# Altium schematic sheet WlPK1_main.SchDoc(WlPK1_main)
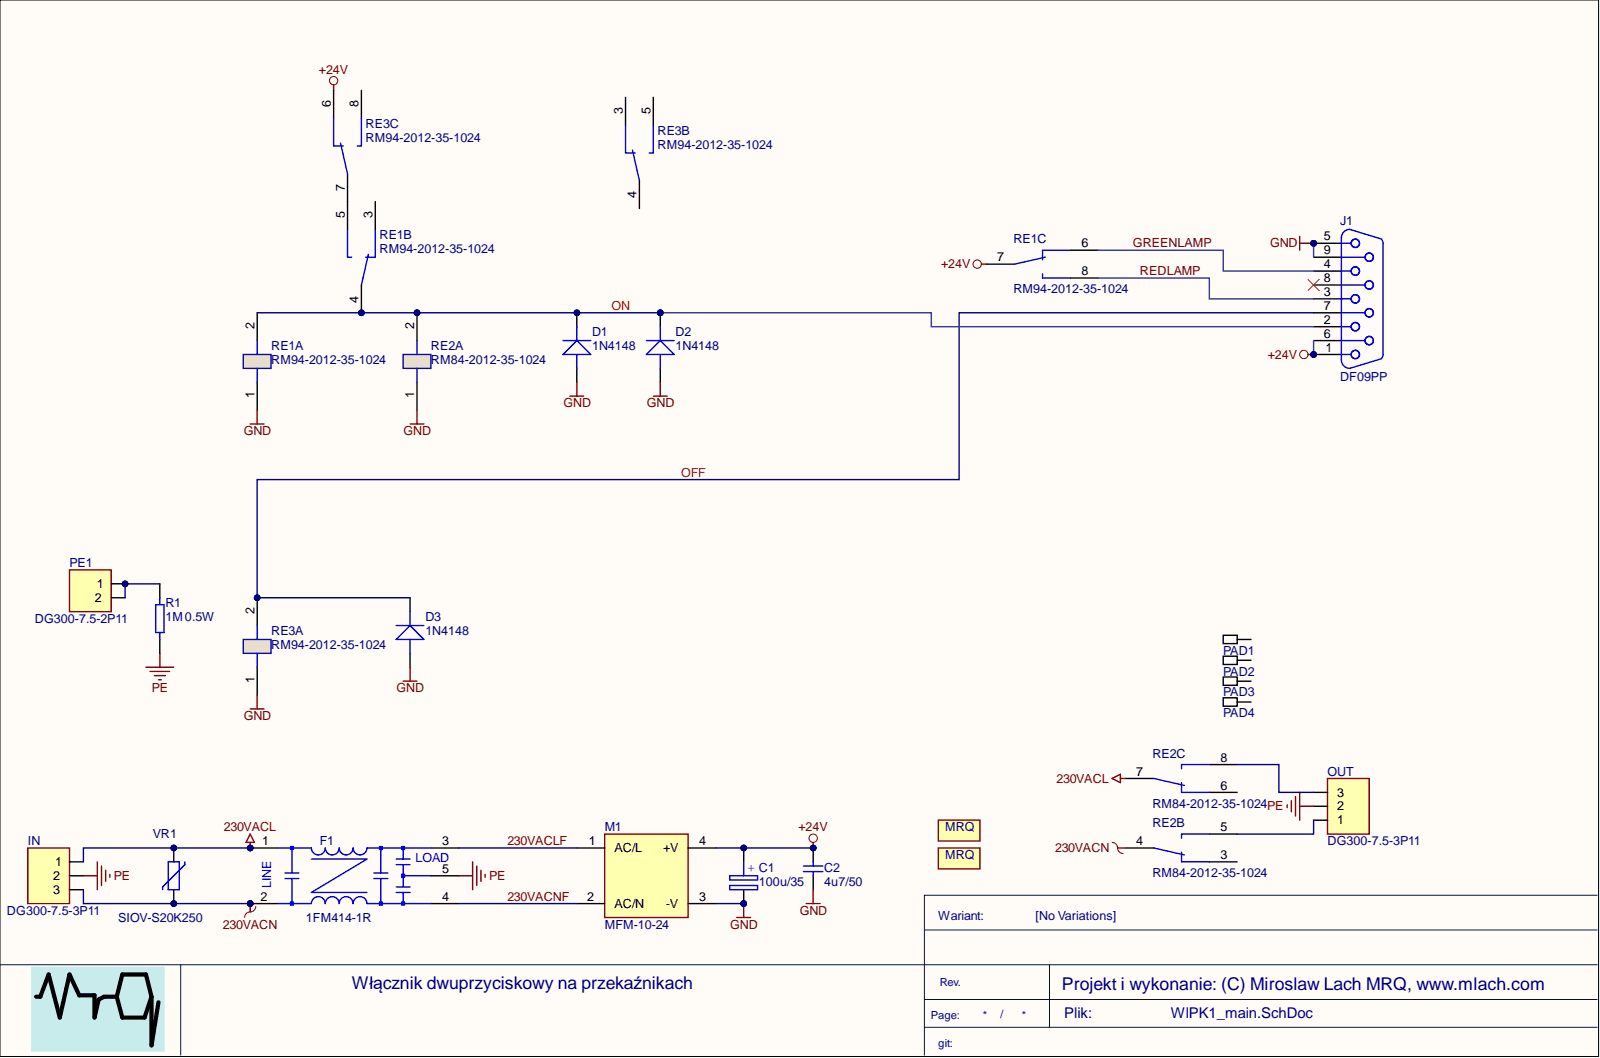
[diagram p0: WlPK1_main.SchDoc]

## Parts
C1  100u/35  [CE1028]
C2  4u7/50  [1210]
D1  1N4148  [CE02]
D2  1N4148  [CE02]
D3  1N4148  [CE02]
F1  1FM414-1R  [1FM414]
IN  DG300-7.5-3P11  [DG300-7.5-3P11]
J1  DF09PP  [DB9/F]
LOGO1  MRQ_5  [MRQ_5_TL]  (value not drawn)  (no BOM)
LOGO2  MRQ_5  [MRQ_5_TL]  (value not drawn)  (no BOM)
M1  MFM-10-24  [MFM-10-24]
OUT  DG300-7.5-3P11  [DG300-7.5-3P11]
PAD1  PAD3_5MM  [PAD3_5MM]  (value not drawn)  (no BOM)
PAD2  PAD3_5MM  [PAD3_5MM]  (value not drawn)  (no BOM)
PAD3  PAD3_5MM  [PAD3_5MM]  (value not drawn)  (no BOM)
PAD4  PAD3_5MM  [PAD3_5MM]  (value not drawn)  (no BOM)
PE1  DG300-7.5-2P11  [DG300-7.5-2P11]
R1  1M 0.5W  [R502816]
RE1  RM94-2012-35-1024  [RM84-P]  (parts A,B,C)
RE2  RM84-2012-35-1024  [RM84-P]  (parts A,B,C)
RE3  RM94-2012-35-1024  [RM84-P]  (parts A,B,C)
VR1  SIOV-S20K250  [SIOV-S20K275]

## Nets
230VACL: F1.1 IN.1 RE2.7 VR1.1
230VACN: F1.2 IN.3 RE2.4 VR1.2
+24V: C1.2 C2.1 J1.1 J1.6 M1.4(+V) RE1.7 RE3.6
GND: C1.1 C2.2 D1.ANOD D2.ANOD D3.ANOD J1.5 J1.9 M1.3(-V) RE1.1 RE2.1 RE3.1
PE: F1.5 IN.2 OUT.2 R1.1
230VACLF: F1.3 M1.1(AC/L)
230VACNF: F1.4 M1.2(AC/N)
GREENLAMP: J1.4 RE1.6
OFF: D3.CATH J1.7 RE3.2
ON: D1.CATH D2.CATH J1.2 RE1.2 RE1.4 RE2.2
REDLAMP: J1.3 RE1.8
NetOUT_1: OUT.1 RE2.5
NetOUT_3: OUT.3 RE2.8
NetPE1_1: PE1.1 PE1.2 R1.2
NetRE1_5: RE1.5 RE3.7

Not connected: J1 8; PAD1 1; PAD2 1; PAD3 1; PAD4 1; RE1 3; RE2 3 6; RE3 3 4 5 8

## Library data of the parts
C1 = CAP15: Database Table Name: Capacitors; Descript: Kondensator: elektrolityczny; THT; 100uF; 35VDC; Ø6,3x11mm; ±20%; ID: 15; Reference5: SD1V107M6L011BB; Component Kind: Standard; DateOfPrice5: 2020-11-08; Identyfikator: 15; Library Name: PartsMySQL.DbLib; Manufacturer5: SAMHA; PartIdx: CAP15; Price5: 0,25; Supplier5: tme.pl; Typ5: SD1V107M6L011BB; Value: 100u/35
C2 = CAP99: Database Table Name: Capacitors; Descript: Kondensator: ceramiczny; 4,7uF; 50V; X7R; ±10%; SMD; 1210; -55÷125°C; ID: 99; Reference5: CL32B475KBUYNNE; Component Kind: Standard; DateOfPrice5: 2020-11-08; Identyfikator: 99; Library Name: PartsMySQL.DbLib; Manufacturer5: Samsung; PartIdx: CAP99; Price5: 1,9; Supplier5: tme.pl; Typ5: CL32B475KBUYNNE; Value: 4u7/50
D1-D3 = DIO2: Database Table Name: Diodes; Descript: Dioda: przełączająca; 100V; 300mA; 2A; DO35; ID: 2; Reference5: 1N4148-TAP; Component Kind: Standard; DateOfPrice5: 2020-11-08; Identyfikator: 2; Library Name: PartsMySQL.DbLib; Manufacturer5: Vishay; PartIdx: DIO2; Price5: 0,3; Supplier5: tme.pl; Typ5: 1N4148-TAP; Value: 1N4148
F1 = Ind14: Database Table Name: Inductors; Descript: Radio filter 250VAC, 1A; ID: 14; Component Kind: Standard; DateOfPrice5: 2020-11-08; Identyfikator: 14; InductCurr: -; Library Name: PartsMySQL.DbLib; Manufacturer5: FilterCon; PartIdx: Ind14; Price5: 16,5; Reference5: 1FM414-1R; Supplier5: tme.pl; Typ5: -; Value: 1FM414-1R
IN OUT = Con33: Database Table Name: Connectors; Descript: Listwa zaciskowa; kątowe 90°; 2,5mm2; 7,5mm; tory:3; cynowany; 24A; ID: 33; Replacement: maritex: TB7503S; Typ5: DG300-7.5-03P-11-00A(H); Component Kind: Standard; DateOfPrice5: 2020-11-08; Identyfikator: 33; Library Name: PartsMySQL.DbLib; Manufacturer5: Degson; PartIdx: Con33; Price5: 1,5; Reference5: DG300-7.5-3P11; Supplier5: tme.pl; Value: DG300-7.5-3P11
J1 = Con312: Database Table Name: Connectors; Descript: Gniazdo; D-Sub; PIN:9; żeńskie; proste; THT; złocony; ID: 312; Replacement: bd; Typ5: DS1034-09FUNSISS; Component Kind: Standard; DateOfPrice5: 2020-11-08; Kod: Con312; Library Name: PartsMySQL.DbLib; Manufacturer5: Connfly; Price5: 2; Reference5: DF09PP; Supplier5: tme.pl; Value: DF09PP
LOGO1 LOGO2 = Oth88: Database Table Name: Other; Descript: bd; ID: 88; DateOfPrice5: 2010-01-01; Kod: Oth88; Library Name: PartsMySQL.DbLib; Manufacturer5: bd; Price5: -1; Reference5: bd; Supplier5: bd; Typ5: bd; Value: MRQ_5
M1 = Mod92: Database Table Name: Modules; Descript: Zasilacz: impulsowy; 10W; 80÷264VAC; Wyjścia: 1; 420mA; -30÷85°C; ID: 92; Component Kind: Standard; DateOfPrice5: 2010-01-01; Identyfikator: 92; Library Name: PartsMySQL.DbLib; Manufacturer5: MeanWell; PartIdx: Mod92; Price5: 35; Reference5: MFM-10-24; Supplier5: tme.pl; Typ5: MFM-10-24; Value: MFM-10-24
PAD1-PAD4 = Oth106: Database Table Name: Other; Descript: bd; ID: 106; DateOfPrice5: 2010-01-01; Identyfikator: 106; Library Name: PartsMySQL.DbLib; Manufacturer5: bd; PartIdx: Oth106; Price5: -1; Reference5: bd; Supplier5: bd; Typ5: bd; Value: PAD3_5MM
PE1 = Con32: Database Table Name: Connectors; Descript: Listwa zaciskowa; kątowe 90°; 2,5mm2; 7,5mm; tory:2; cynowany; 24A; ID: 32; Replacement: maritex: TB7502S; Typ5: DG300-7.5-02P-11-00A(H); Component Kind: Standard; DateOfPrice5: 2020-11-08; Kod: Con32; Library Name: PartsMySQL.DbLib; Manufacturer5: Degson; Price5: 1; Reference5: DG300-7.5-2P11; Supplier5: tme.pl; Value: DG300-7.5-2P11
R1 = Res69: Database Table Name: Resistors; Descript: Resistor 1 megaohm, 0.5W, 5%; ID: 69; Reference5: 1/2W-1M; Typ5: brak danych; Component Kind: Standard; DateOfPrice5: 2020-06-25; Identyfikator: 69; Library Name: PartsMySQL.DbLib; Manufacturer5: brak danych; PartIdx: Res69; Price5: 0,2; Supplier5: tme.pl; Value: 1M 0.5W
RE1 RE3 = Rel24: Database Table Name: Relays; Descript: Przekaźnik: elektromagnetyczny; DPDT; Ucewki : 24VDC; 8A/250VAC, nie produkowany; ID: 24; Component Kind: Standard; DateOfPrice5: 2020-07-19; Kod: Rel24; Library Name: PartsMySQL.DbLib; Manufacturer5: Relpol; Price5: 5; Reference5: RM94-2012-35-1024; Supplier5: -; Typ5: RM94-2012-35-1024; Value: RM94-2012-35-1024
RE2 = Rel14: Database Table Name: Relays; Descript: Przekaźnik: elektromagnetyczny; DPDT; Ucewki : 24VDC; 8A/250VAC; ID: 14; Component Kind: Standard; DateOfPrice5: 2010-01-01; Kod: Rel14; Library Name: PartsMySQL.DbLib; Manufacturer5: Relpol; Price5: 6,5; Reference5: RM84-2012-35-1024; Supplier5: tme.pl; Typ5: RM84-2012-35-1024; Value: RM84-2012-35-1024
VR1 = Vre35: Database Table Name: VRes; Descript: Warystor: metalowo-tlenkowy; THT; 250VAC; 320VDC; 390V; 8000A; 140J; ID: 35; Component Kind: Standard; DateOfPrice5: 2020-11-08; Identyfikator: 35; Library Name: PartsMySQL.DbLib; Manufacturer5: Epcos; PartIdx: Vre35; Price5: 3; Reference5: SIOV-S20K250; Supplier5: tme.pl; Typ5: S20K250; Value: SIOV-S20K250
